# Altium schematic sheet YomraGMPCB.SchDoc
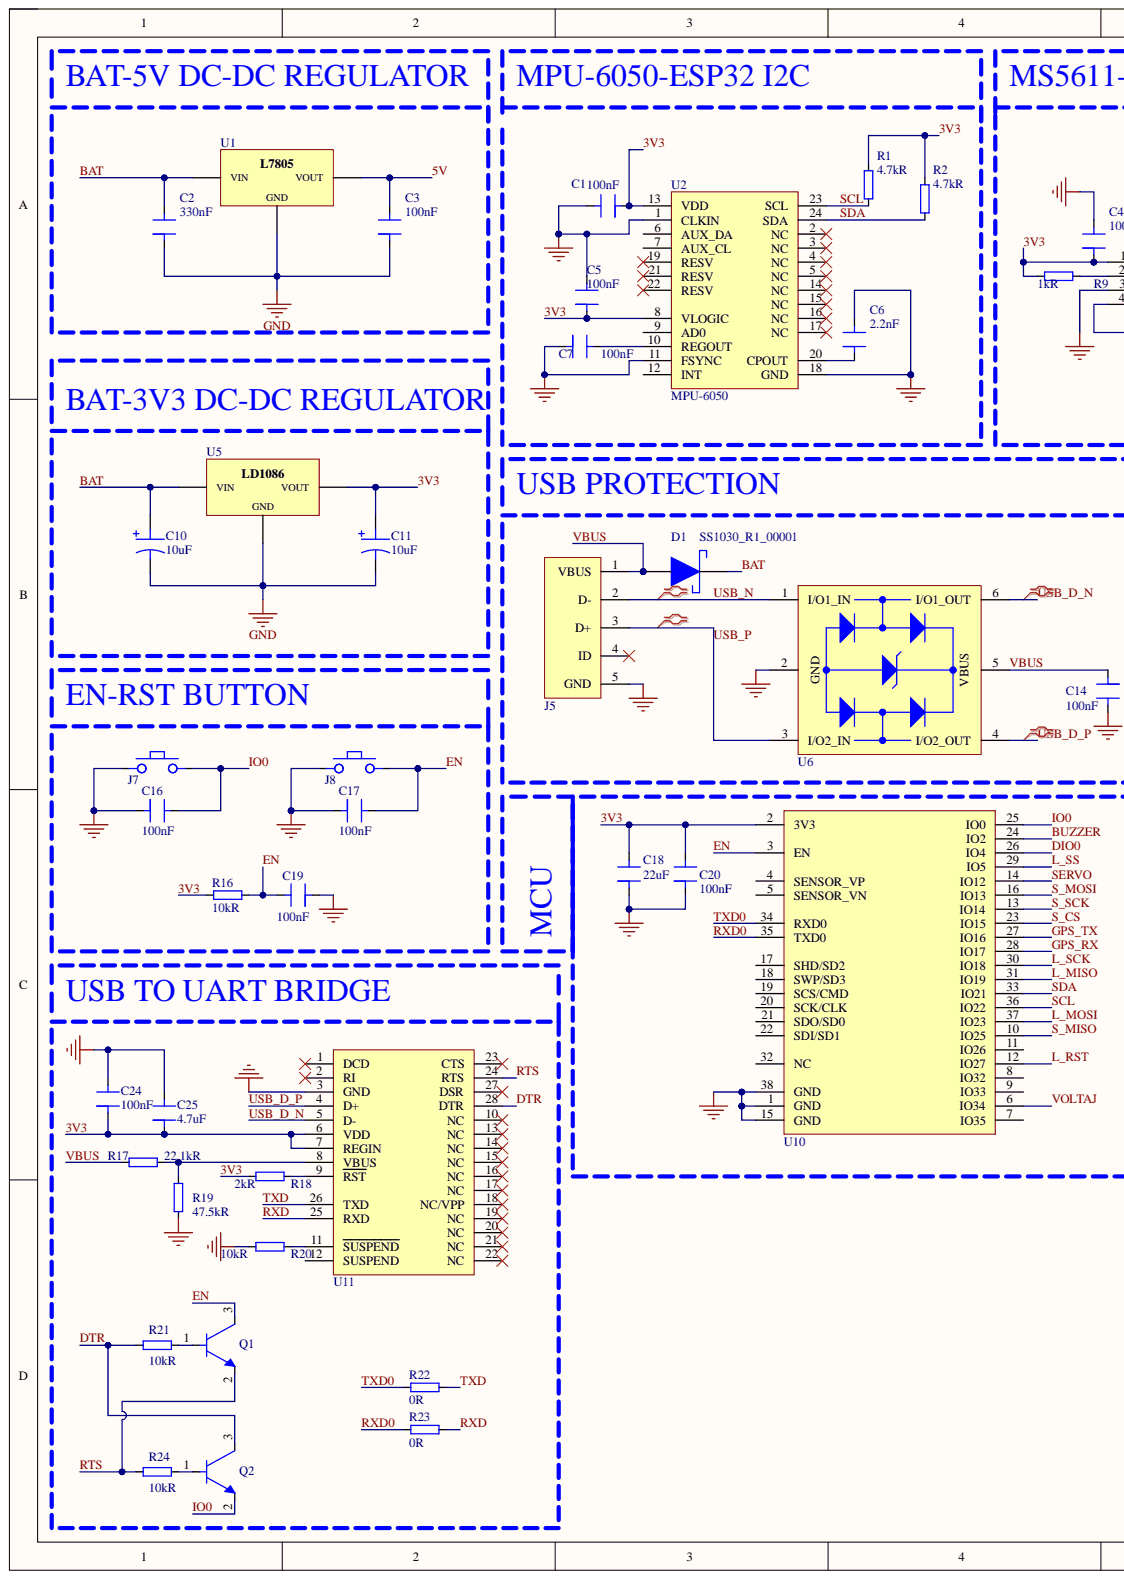
[diagram p0: YomraGMPCB.SchDoc, left]
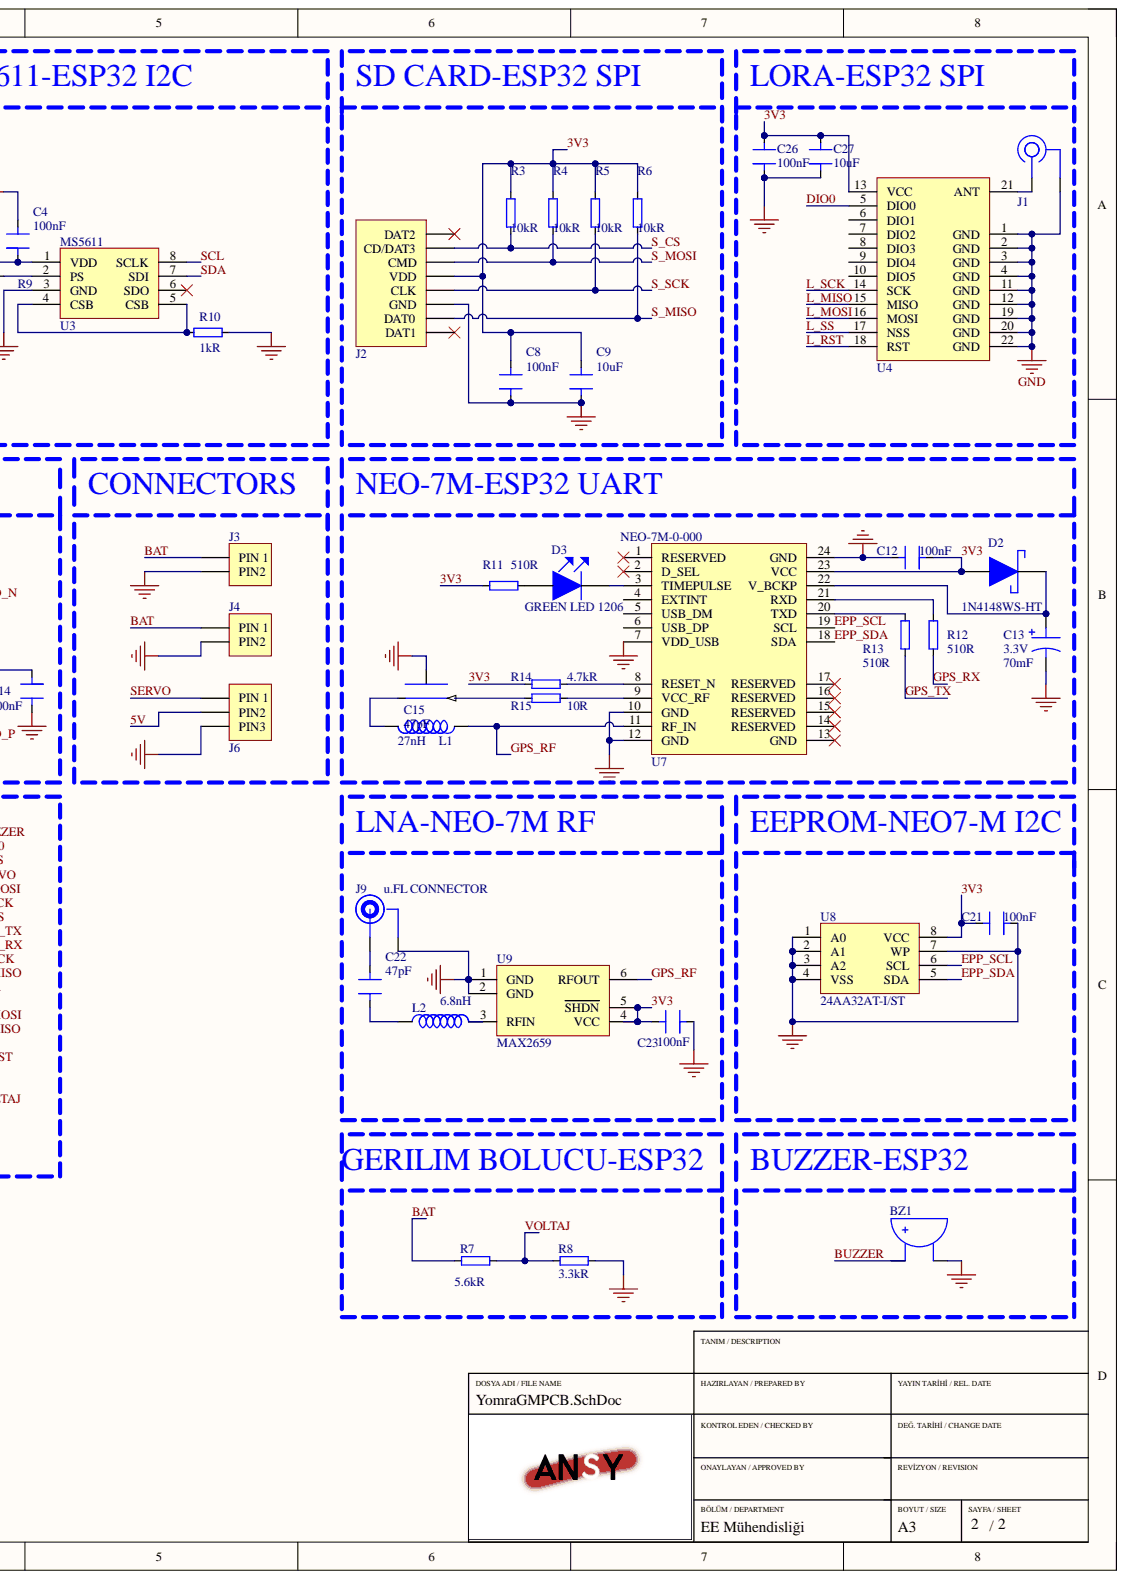
[diagram p1: YomraGMPCB.SchDoc, right]

## Parts
BZ1  YX-YT12075-YT03D  [BUZZER 12mm]  (value not drawn)
C1  Capacitance: 100nF  [CAP 1206]  (hidden comment: CC1206KRX7R9BB104)
C2  Capacitance: 330nF  [CAP 1206]  (hidden comment: CL31B334KACNNNC)
C3  Capacitance: 100nF  [CAP 1206]  (hidden comment: CC1206KRX7R9BB104)
C4  Capacitance: 100nF  [CAP 1206]  (hidden comment: CC1206KRX7R9BB104)
C5  Capacitance: 100nF  [CAP 1206]  (hidden comment: CC1206KRX7R9BB104)
C6  Capacitance: 2.2nF  [CAP 1206]  (hidden comment: CC1206KRX7R9BB222)
C7  Capacitance: 100nF  [CAP 1206]  (hidden comment: CC1206KRX7R9BB104)
C8  Capacitance: 100nF  [CAP 1206]  (hidden comment: CC1206KRX7R9BB104)
C9  Capacitance: 10uF  [CAP 1206]  (hidden comment: CL31B106KAHNNNE)
C10  Capacitance: 10uF  [TANTAL B CASE 3528]  (hidden comment: T491B106K016AT)
C11  Capacitance: 10uF  [TANTAL B CASE 3528]  (hidden comment: T491B106K016AT)
C12  Capacitance: 100nF  [CAP 1206]  (hidden comment: CC1206KRX7R9BB104)
C13  Capacitance: 70mF  Voltage Rating: 3.3V  [COIN GPS]  (hidden comment: DSK-3R3H703T414-HRL)
C14  Capacitance: 100nF  [CAP 1206]  (hidden comment: CC1206KRX7R9BB104)
C15  Capacitance: 47pF  [CAP FEEDTHRU 1206]  (hidden comment: W3F11A4708AT1F)
C16  Capacitance: 100nF  [CAP 1206]  (hidden comment: CC1206KRX7R9BB104)
C17  Capacitance: 100nF  [CAP 1206]  (hidden comment: CC1206KRX7R9BB104)
C18  Capacitance: 22uF  [CAP 1206]  (hidden comment: CL31B226KPHNNNE)
C19  Capacitance: 100nF  [CAP 1206]  (hidden comment: CC1206KRX7R9BB104)
C20  Capacitance: 100nF  [CAP 1206]  (hidden comment: CC1206KRX7R9BB104)
C21  Capacitance: 100nF  [CAP 1206]  (hidden comment: CC1206KRX7R9BB104)
C22  Capacitance: 47pF  [CAP 1206]  (hidden comment: 885012008021)
C23  Capacitance: 100nF  [CAP 1206]  (hidden comment: CC1206KRX7R9BB104)
C24  Capacitance: 100nF  [CAP 1206]  (hidden comment: CC1206KRX7R9BB104)
C25  Capacitance: 4.7uF  [CAP 1206]  (hidden comment: C1206C475K8RACTU)
C26  Capacitance: 100nF  [CAP 1206]  (hidden comment: CC1206KRX7R9BB104)
C27  Capacitance: 10uF  [CAP 1206]  (hidden comment: CL31B106KAHNNNE)
D1  SS1030_R1_00001  [SOD-123]
D2  1N4148WS-HT  [SOD-323]
D3  GREEN LED 1206  [1206 LED GREEN]
J1  732-13835-ND  [SMA PCB THT Jack Straight]  (value not drawn)
J2  MOLEX-5027740891  [SD CARD CONNECTOR]  (value not drawn)
J3  2*1 JST  [2*1 JST]  (value not drawn)
J4  2*1 JST  [2*1 JST]  (value not drawn)
J5  MICRO USB 2.0 B TYPE  [MICRO USB 2.0 B TYPE]  (value not drawn)
J6  3*1 Header  [3*1 Header]  (value not drawn)
J7  SWITCH TACT BUTTON 6x6MM  [SWITCH TACT BUTTON 6x6MM]  (value not drawn)
J8  SWITCH TACT BUTTON 6x6MM  [SWITCH TACT BUTTON 6x6MM]  (value not drawn)
J9  u.FL CONNECTOR  [u.FL SMD CONNECTOR]
L1  Inductance: 27nH  [IND 0402]  (hidden comment: LQG15HS27NJ02D)
L2  HCL1608-6N8J-N  [IND 0603]  (value not drawn)
Q1  TRANS NPN 25V 1.5A SOT23-3  [SOT23]  (value not drawn)
Q2  TRANS NPN 25V 1.5A SOT23-3  [SOT23]  (value not drawn)
R1  Resistance: 4.7kR  [RES 1206]  (hidden comment: 1206S4F4701T5E)
R2  Resistance: 4.7kR  [RES 1206]  (hidden comment: 1206S4F4701T5E)
R3  Resistance: 10kR  [RES 1206]  (hidden comment: 1206S4J0103T5E)
R4  Resistance: 10kR  [RES 1206]  (hidden comment: 1206S4J0103T5E)
R5  Resistance: 10kR  [RES 1206]  (hidden comment: 1206S4J0103T5E)
R6  Resistance: 10kR  [RES 1206]  (hidden comment: 1206S4J0103T5E)
R7  Resistance: 5.6kR  [RES 1206]  (hidden comment: 1206S4F5601T5E)
R8  Resistance: 3.3kR  [RES 1206]  (hidden comment: 1206S4F3301T5E)
R9  Resistance: 1kR  [RES 1206]  (hidden comment: 1206S4J0102T5E)
R10  Resistance: 1kR  [RES 1206]  (hidden comment: 1206S4J0102T5E)
R11  1206S4J0511T5E  [RES 1206]  (value not drawn)
R12  Resistance: 510R  [RES 1206]  (hidden comment: 1206S4J0511T5E)
R13  Resistance: 510R  [RES 1206]  (hidden comment: 1206S4J0511T5E)
R14  Resistance: 4.7kR  [RES 1206]  (hidden comment: 1206S4F4701T5E)
R15  Resistance: 10R  [RES 1206]  (hidden comment: 1206S4J0100T5E)
R16  Resistance: 10kR  [RES 1206]  (hidden comment: 1206S4J0103T5E)
R17  Resistance: 22.1kR  [RES 1206]  (hidden comment: 1206S4F2212T5E)
R18  Resistance: 2kR  [RES 1206]  (hidden comment: CQ06S4J0202T5E)
R19  Resistance: 47.5kR  [RES 1206]  (hidden comment: 1206S4F4752T5E)
R20  Resistance: 10kR  [RES 1206]  (hidden comment: 1206S4J0103T5E)
R21  Resistance: 10kR  [RES 1206]  (hidden comment: 1206S4J0103T5E)
R22  Resistance: 0R  [RES 1206]  (hidden comment: 1206S4J0000T5E)
R23  Resistance: 0R  [RES 1206]  (hidden comment: 1206S4J0000T5E)
R24  Resistance: 10kR  [RES 1206]  (hidden comment: 1206S4J0103T5E)
U1  IC-7805 V.REG.+5V 1.5A DPAK TO252  [DPAK TO252]  (value not drawn)
U2  MPU-6050  [24-VFQFN]
U3  MS5611  [QFN 8 PIN 5*3*1]
U4  LoRa  [LoRa 433M20S2]  (value not drawn)
U5  LD1086DT33TR - IC-1086 V.REG LDO 3.3V 1.5A TO-252 (DPAK)  [DPAK TO252]  (value not drawn)
U6  USBLC6-2SC6  [SOT23-6]  (value not drawn)
U7  NEO-7M-0-000  [NEO-7M]
U8  24AA32AT-I/ST  [8TSSOP]
U9  MAX2659  [6 µDFN]
U10  ESP32-WROOM-32E (4MB)  [ESP32-WROOM-32U]  (value not drawn)
U11  IC-2102 USB-TO-UART BRIDGE 28VQFN  [QFN-28]  (value not drawn)

## Nets
GND: BZ1.2 C1.2 C2.1 C3.1 C4.2 C5.2 C6.2 C7.1 C8.1 C9.1 C10.2 C11.2 C12.2 C13.2 C14.2 C15.3 C16.1 C17.1 C18.1 C19.1 C20.1 C21.1 C23.1 C24.2 C25.2 C26.1 C27.1 J1.2 J2.6(GND) J3.2 J4.2 J5.5(GND) J6.3 J7.1 J8.1 J9.2 R8.1 R10.2 R19.1 R20.1 U1.2 U2.1(CLKIN) U2.11(FSYNC) U2.18(GND) U3.3(GND) U4.1(GND) U4.2(GND) U4.3(GND) U4.4(GND) U4.11(GND) U4.12(GND) U4.19(GND) U4.20(GND) U4.22(GND) U5.2 U6.2 U7.7(VDD_USB) U7.10(GND) U7.12(GND) U7.24(GND) U8.1(A0) U8.2(A1) U8.3(A2) U8.4(VSS) U8.7(WP) U9.1(GND) U9.2(GND) U10.1(GND) U10.15(GND) U10.38(GND) U11.3(GND)
3V3: C1.1 C4.1 C5.1 C8.2 C9.2 C11.1 C12.1 C18.2 C20.2 C21.2 C23.2 C24.1 C25.1 C26.2 C27.2 D2.1 J2.4(VDD) R1.2 R2.2 R3.2 R4.2 R5.2 R6.2 R9.1 R11.1 R14.1 R16.2 R18.1 U2.8(VLOGIC) U2.13(VDD) U3.1(VDD) U4.13(VCC) U5.3 U7.23(VCC) U8.8(VCC) U9.4(VCC) U9.5(SHDN) U10.2(3V3) U11.6(VDD) U11.7(REGIN)
5V: C3.2 J6.2 U1.3
BAT: C2.2 C10.1 D1.2 J3.1 J4.1 R7.1 U1.1 U5.1
BUZZER: BZ1.1 U10.24(IO2)
DIO0: U4.5(DIO0) U10.26(IO4)
DTR: Q2.3 R21.1 U11.28(DTR)
EN: C17.2 C19.2 J8.2 Q1.3 R16.1 U10.3(EN)
EPP_SCL: U7.19(SCL) U8.6(SCL)
EPP_SDA: U7.18(SDA) U8.5(SDA)
GPS_RF: L1.1 U7.11(RF_IN) U9.6(RFOUT)
GPS_RX: R12.2 U10.28(IO17)
GPS_TX: R13.2 U10.27(IO16)
IO0: C16.2 J7.2 Q2.2 U10.25(IO0)
L_MISO: U4.15(MISO) U10.31(IO19)
L_MOSI: U4.16(MOSI) U10.37(IO23)
L_RST: U4.18(RST) U10.12(IO27)
L_SCK: U4.14(SCK) U10.30(IO18)
L_SS: U4.17(NSS) U10.29(IO5)
RTS: Q1.2 R24.1 U11.24(RTS)
RXD: R23.2 U11.25(RXD)
RXD0: R23.1 U10.35(TXD0)
S_CS: J2.2(CD/DAT3) R3.1 U10.23(IO15)
S_MISO: J2.7(DAT0) R6.1 U10.10(IO25)
S_MOSI: J2.3(CMD) R4.1 U10.16(IO13)
S_SCK: J2.5(CLK) R5.1 U10.13(IO14)
SCL: R1.1 U2.23(SCL) U3.8(SCLK) U10.36(IO22)
SDA: R2.1 U2.24(SDA) U3.7(SDI) U10.33(IO21)
SERVO: J6.1 U10.14(IO12)
TXD: R22.2 U11.26(TXD)
TXD0: R22.1 U10.34(RXD0)
USB_D_N: U6.6(I/O1_OUT) U11.5(D-)
USB_D_P: U6.4(I/O2_OUT) U11.4(D+)
USB_N: J5.2(D-) U6.1(I/O1_IN)
USB_P: J5.3(D+) U6.3(I/O2_IN)
VBUS: C14.1 D1.1 J5.1(VBUS) R17.2 U6.5
VOLTAJ: R7.2 R8.2 U10.6(IO34)
NetC6_1: C6.1 U2.20(CPOUT)
NetC7_2: C7.2 U2.10(REGOUT)
NetC13_1: C13.1 D2.2 U7.22(V_BCKP)
NetC15_1: C15.1 R15.1
NetC15_2: C15.2 L1.2
NetC22_1: C22.1 L2.1
NetC22_2: C22.2 J9.1
NetD3_1: D3.1 R11.2
NetD3_2: D3.2 U7.3(TIMEPULSE)
NetJ1_1: J1.1 U4.21(ANT)
NetL2_2: L2.2 U9.3(RFIN)
NetQ1_1: Q1.1 R21.2
NetQ2_1: Q2.1 R24.2
NetR9_2: R9.2 U3.2(PS)
NetR10_1: R10.1 U3.4(CSB) U3.5(CSB)
NetR12_1: R12.1 U7.21(RXD)
NetR13_1: R13.1 U7.20(TXD)
NetR14_2: R14.2 U7.8(RESET_N)
NetR15_2: R15.2 U7.9(VCC_RF)
NetR17_1: R17.1 R19.2 U11.8(VBUS)
NetR18_2: R18.2 U11.9(RST)
NetR20_2: R20.2 U11.11(SUSPEND)

Not connected: J2 1(DAT2) 8(DAT1); J5 4(ID); U2 2(NC) 3(NC) 4(NC) 5(NC) 6(AUX_DA) 7(AUX_CL) 9(AD0) 12(INT) 14(NC) 15(NC) 16(NC) 17(NC) 19(RESV) 21(RESV) 22(RESV); U3 6(SDO); U4 6(DIO1) 7(DIO2) 8(DIO3) 9(DIO4) 10(DIO5); U7 1(RESERVED) 2(D_SEL) 4(EXTINT) 5(USB_DM) 6(USB_DP) 13(GND) 14(RESERVED) 15(RESERVED) 16(RESERVED) 17(RESERVED); U10 4(SENSOR_VP) 5(SENSOR_VN) 7(IO35) 8(IO32) 9(IO33) 11(IO26) 17(SHD/SD2) 18(SWP/SD3) 19(SCS/CMD) 20(SCK/CLK) 21(SDO/SD0) 22(SDI/SD1) 32(NC); U11 1(DCD) 2(RI) 10(NC) 12(SUSPEND) 13(NC) 14(NC) 15(NC) 16(NC) 17(NC) 18(NC/VPP) 19(NC) 20(NC) 21(NC) 22(NC) 23(CTS) 27(DSR)
